# Altium schematic sheet Sheet1.SchDoc(Sheet1)
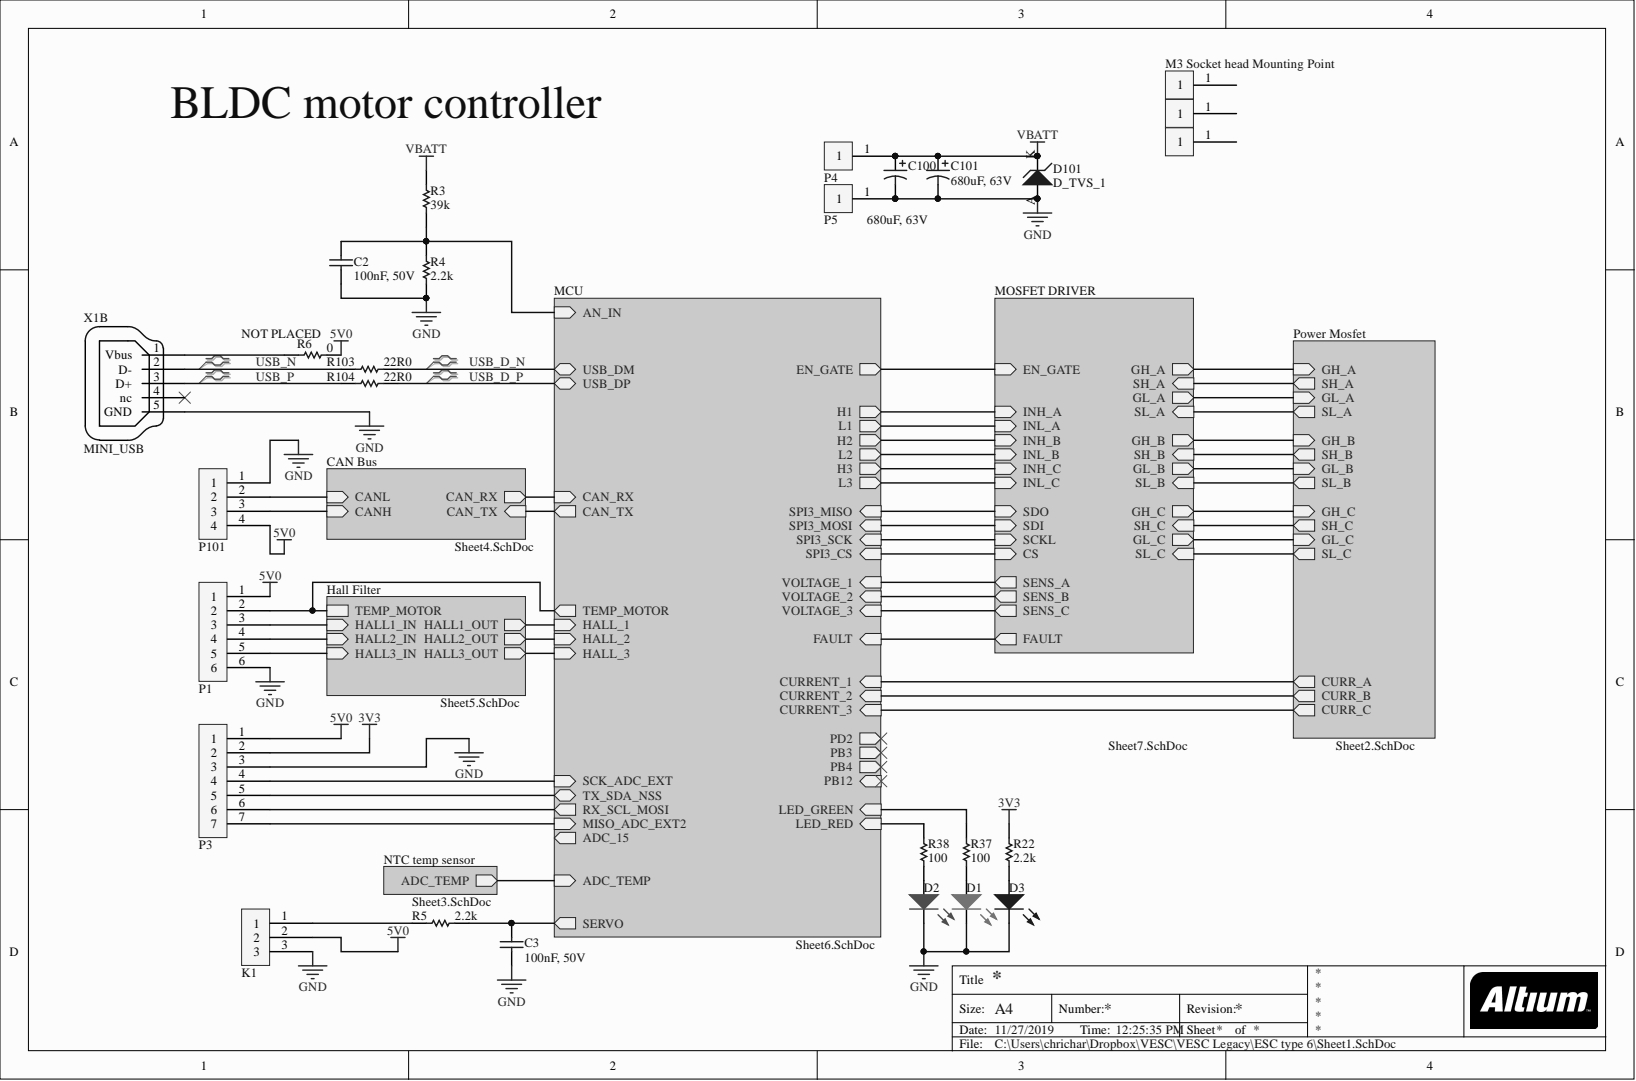
[diagram p0: Sheet1.SchDoc]

## Parts
C2  value 100nF, 50V  [SMD-0603 Capacitor]  (hidden comment: Voltage important)
C3  value 100nF, 50V  [SMD-0603 Capacitor]  (hidden comment: Voltage important)
C100  value 680uF, 63V  [ALU CAP 16DIA 25HIGH]  (hidden comment: CAP_POL)
C101  value 680uF, 63V  [ALU CAP 16DIA 25HIGH]  (hidden comment: CAP_POL)
D1  LED_GREEN  [SMD-0603 LED GREEN]
D2  LED_RED  [SMD-0603 LED RED]  (value not drawn)
D3  LED_BLUE  [SMD-0603 LED BLUE]  (value not drawn)
D101  D_TVS_1  [SMC-403-03]
K1  -  [HEADER 3 PIN]  (value not drawn)
M1  M3 Socket head Mounting Point  value M3  [M3 Socket head]
M2  M3 Socket head Mounting Point  [M3 Socket head]
M3  M3 Socket head Mounting Point  [M3 Socket head]
P1  B6B-PH-K-S(LF)(SN)  [JST PH TH VERT 6]  (value not drawn)
P3  B7B-PH-K-S(LF)(SN)  [JST PH TH VERT 7]  (value not drawn)
P4  -  [PAD1]  (value not drawn)
P5  -  [PAD1]  (value not drawn)
P101  B4B-PH-K-S(LF)(SN)  [JST PH TH VERT 4]  (value not drawn)
R3  39k  [SMD-0603 Resistor]
R4  2.2k  [SMD-0603 Resistor]
R5  2.2k  [SMD-0603 Resistor]
R6  0  Place (YES/NO): NOT PLACED  [SMD-0603 Resistor]
R22  2.2k  [SMD-0603 Resistor]
R37  100  [SMD-0603 Resistor]
R38  100  [SMD-0603 Resistor]
R103  22R0  [SMD-0603 Resistor]
R104  22R0  [SMD-0603 Resistor]
X1B  MINI_USB  [USB-MICRO B RA SMT-TH]

## Nets
3V3: P3.2 R22.2
5V0: K1.2 P1.1 P3.1 P101.4 R6.2
GND: C2.2 C3.1 C100.2 C101.2 D1.2 D2.2 D3.2 D101.A K1.3 P1.6 P3.3 P5.1 P101.1 R4.1 X1B.5(GND)
VBATT: C100.1 C101.1 D101.K P4.1 R3.2
USB_D_N: R103.2
USB_D_P: R104.2
USB_N: R103.1 X1B.2(D-)
USB_P: R104.1 X1B.3(D+)
NetC2_1: C2.1 R3.1 R4.2
NetC3_2: C3.2 R5.2
NetD1_1: D1.1 R37.1
NetD2_1: D2.1 R38.1
NetD3_1: D3.1 R22.1
NetK1_1: K1.1 R5.1
NetM1_1: M1.1
NetM2_1: M2.1
NetM3_1: M3.1
NetP1_2: P1.2
NetP1_3: P1.3
NetP1_4: P1.4
NetP1_5: P1.5
NetP3_4: P3.4
NetP3_5: P3.5
NetP3_6: P3.6
NetP3_7: P3.7
NetP101_2: P101.2
NetP101_3: P101.3
NetR6_1: R6.1 X1B.1(Vbus)
NetR37_2: R37.2
NetR38_2: R38.2
NetX1B_4: X1B.4(nc)
ADC_15:
ADC_TEMP:
CAN_RX:
CAN_TX:
CURRENT_1:
CURRENT_2:
CURRENT_3:
EN_GATE:
FAULT:
GH_A:
GH_B:
GH_C:
GL_A:
GL_B:
GL_C:
H1:
H2:
H3:
HALL1_OUT:
HALL2_OUT:
HALL3_OUT:
L1:
L2:
L3:
PB3:
PB4:
PB12:
PD2:
SH_A:
SH_B:
SH_C:
SL_A:
SL_B:
SL_C:
SPI3_CS:
SPI3_MISO:
SPI3_MOSI:
SPI3_SCK:
VOLTAGE_1:
VOLTAGE_2:
VOLTAGE_3:

## Library data of the parts
C2 = 7: CAP 100n, 50V, 0603; Manufacturer Part Number: 06035C104JAT2A; Manufacturer: AVX Corporation; Value: 100nF, 50V; Place (YES/NO): YES; Database Table Name: Capacitor$; Library Name: Master Data Link.DbLib; ID: 7; Component Kind: Standard
C3 = 7: CAP 100n, 50V, 0603; Manufacturer Part Number: 06035C104JAT2A; Manufacturer: AVX Corporation; Place (YES/NO): YES; Database Table Name: Capacitor$; Library Name: Master Data Link.DbLib; ID: 7; Component Kind: Standard
C100 = 21: 680µF 63V Aluminum Capacitors Radial; Manufacturer Part Number: UPW1J681MHD; Manufacturer: Nichicon; Value: 680uF, 63V; Place (YES/NO): YES; Database Table Name: Capacitor$; Library Name: Master Data Link.DbLib; ID: 21; Component Kind: Standard
C101 = 21: 680µF 63V Aluminum Capacitors Radial; Manufacturer Part Number: UPW1J681MHD; Manufacturer: Nichicon; Place (YES/NO): YES; Database Table Name: Capacitor$; Library Name: Master Data Link.DbLib; ID: 21; Component Kind: Standard
D1 = 1: LED Green, 0603; Part Number: LTST-C191KGKT; Manufacturer: Lite-On Inc.; Place (YES/NO): YES; Database Table Name: Diode$; Manufacturer Part Number: LTST-C191KGKT; Library Name: Master Data Link.DbLib; ID: 1; Component Kind: Standard
D2 = 2: LED Red, 0603; Part Number: LTST-C191KRKT; Manufacturer: Lite-On Inc.; Place (YES/NO): YES; Database Table Name: Diode$; Manufacturer Part Number: LTST-C191KRKT; Library Name: Master Data Link.DbLib; ID: 2; Component Kind: Standard
D3 = 3: LED Blue, 0603; Part Number: LBQ39GN1P1351; Manufacturer: OSRAM; Place (YES/NO): YES; Database Table Name: Diode$; Manufacturer Part Number: LBQ39GN1P1351; Library Name: Master Data Link.DbLib; ID: 3; Component Kind: Standard
D101 = 16: TVS DIODE 51VWM 82.4VC SMC; Manufacturer Part Number: SMCJ51A; Manufacturer: ON Semiconductor; Value: 51V; Place (YES/NO): YES; Database Table Name: Diode$; Library Name: Master Data Link.DbLib; ID: 16; Component Kind: Standard
K1 = 6: Breakaway 3 pin Through hole; Place (YES/NO): YES; Database Table Name: Connector$; Manufacturer Part Number: -; Manufacturer: -; Library Name: Master Data Link.DbLib; ID: 6; Component Kind: Standard
M1-M3 = 1: Place (YES/NO): yes; Database Table Name: Hardware$; Library Name: Master Data Link.DbLib; ID: 1; Description: M3 Socket head Mounting Point; Component Kind: Standard
  M2 M3: Value: M3
P1 = 3: JST PH Through hole Vertical 6 Pin; Value: JST PH 6 PIN; Place (YES/NO): YES; Database Table Name: Connector$; Manufacturer Part Number: B6B-PH-K-S(LF)(SN); Manufacturer: JST; Library Name: Master Data Link.DbLib; ID: 3; Component Kind: Standard
P3 = 4: JST PH Through hole Vertical 7 Pin; Value: JST PH 4 PIN; Place (YES/NO): YES; Database Table Name: Connector$; Manufacturer Part Number: B7B-PH-K-S(LF)(SN); Manufacturer: JST; Library Name: Master Data Link.DbLib; ID: 4; Component Kind: Standard
P4 P5 = 1: SMD Power landing; Database Table Name: No BOM$; Value: -; Place (YES/NO): -; Manufacturer Part Number: -; Manufacturer: -; Library Name: Master Data Link.DbLib; ID: 1; Component Kind: Standard
P101 = 2: JST PH Through hole Vertical 4 Pin; Value: JST PH 4 PIN; Place (YES/NO): YES; Database Table Name: Connector$; Manufacturer Part Number: B4B-PH-K-S(LF)(SN); Manufacturer: JST; Library Name: Master Data Link.DbLib; ID: 2; Component Kind: Standard
R3 = 8: R 39k, 0603; Manufacturer Part Number: ERJ-3EKF3902V; Manufacturer: Panasonic Electronic Components; Place (YES/NO): YES; Database Table Name: Resistor$; Value: 39k; Library Name: Master Data Link.DbLib; ID: 8; Component Kind: Standard
R4 R5 R22 = 3: R 2.2k, 0603; Manufacturer Part Number: RK73H1JTTD2201F; Manufacturer: KOA Speer; Place (YES/NO): YES; Database Table Name: Resistor$; Value: 2.2k; Library Name: Master Data Link.DbLib; ID: 3; Component Kind: Standard
R6 = 16: R 0 ohm, 0603; Manufacturer: KOA Speer; Database Table Name: Resistor$; Value: 0; Library Name: Master Data Link.DbLib; ID: 16; Component Kind: Standard
R37 R38 = 2: R 100ohm, 0603; Manufacturer Part Number: RK73H1JTTD1000F; Manufacturer: KOA Speer; Place (YES/NO): YES; Database Table Name: Resistor$; Value: 100; Library Name: Master Data Link.DbLib; ID: 2; Component Kind: Standard
R103 R104 = 12: R 22R, 0603; Manufacturer Part Number: RK73H1JTTD22R0F; Manufacturer: KOA Speer; Place (YES/NO): YES; Database Table Name: Resistor$; Value: 22R0; Library Name: Master Data Link.DbLib; ID: 12; Component Kind: Standard
X1B = 39: CONN USB MICRO B RECPT SMT R/A TH; Manufacturer Part Number: 10118194-0001LF; Manufacturer: Amphenol FCI; Value: Micro-USB; Place (YES/NO): YES; Database Table Name: Connector$; Library Name: Master Data Link.DbLib; ID: 39; Component Kind: Standard
